# Altium schematic sheet 06_ATK-DLMP257_DEVICE.SchDoc
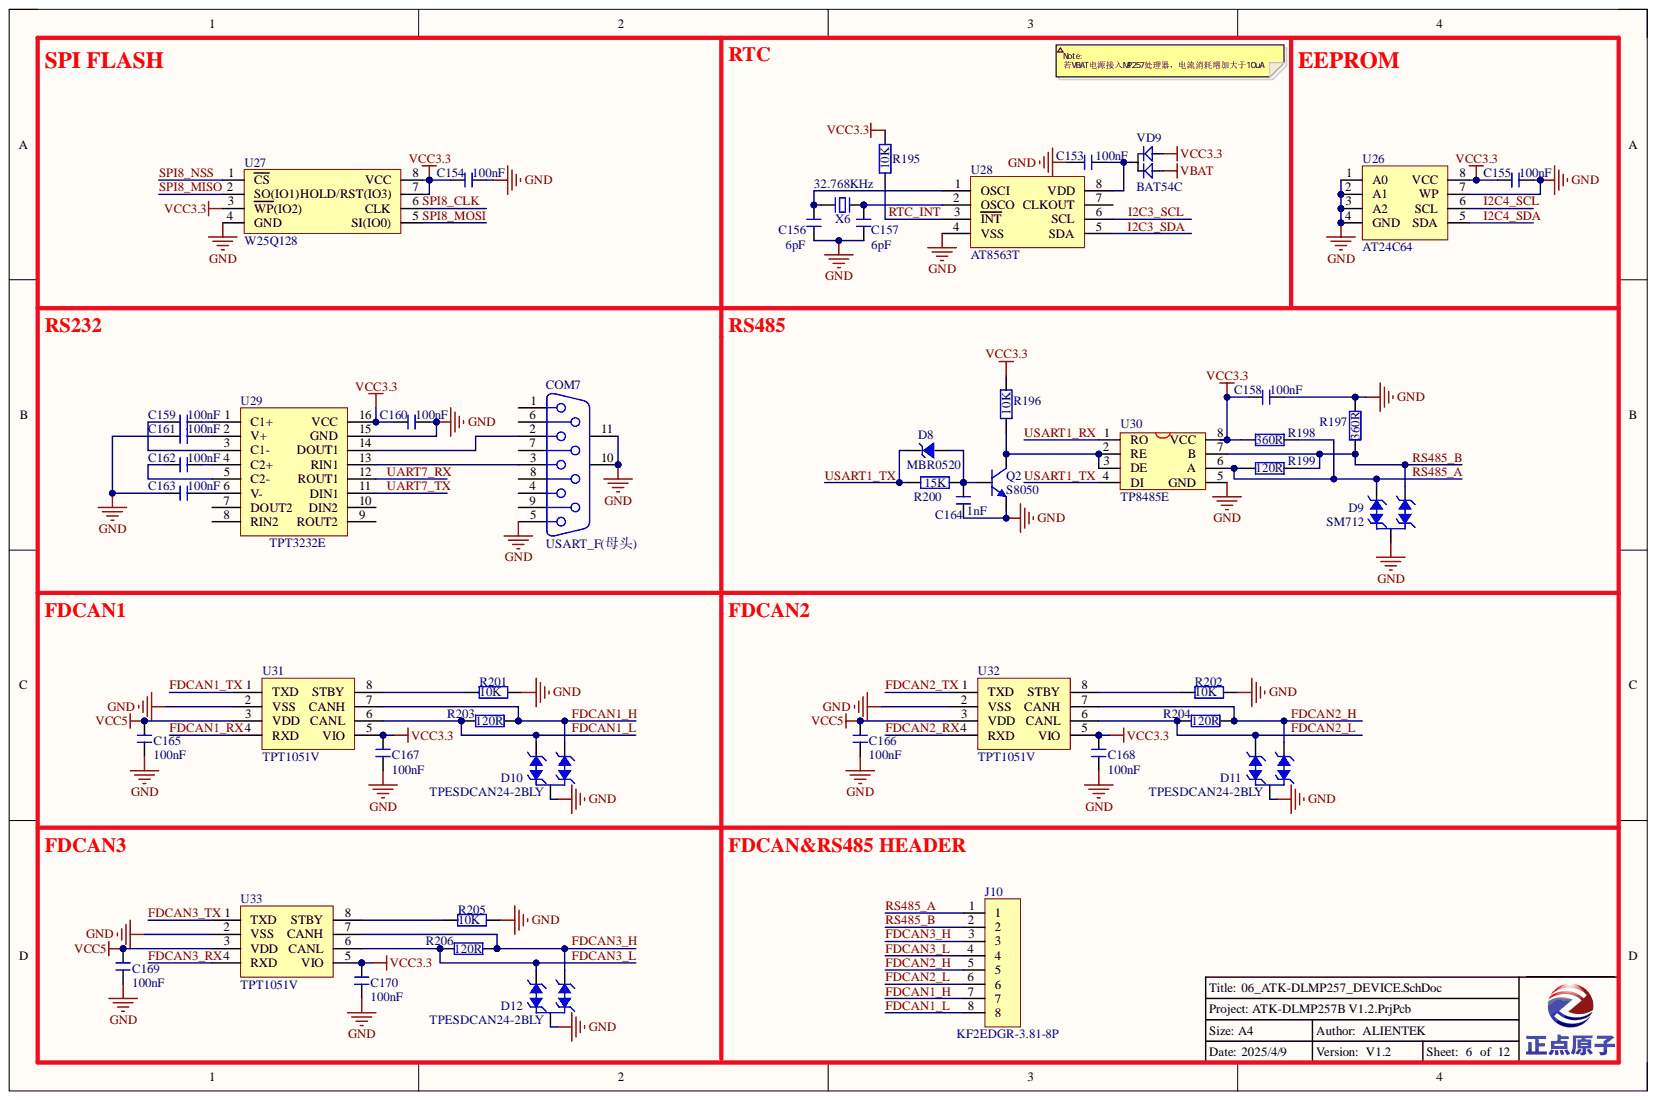
[diagram p0: 06_ATK-DLMP257_DEVICE.SchDoc]

## Parts
C153  100nF  [C 0603]
C154  100nF  [C 0603]
C155  100nF  [C 0603]
C156  6pF  [C 0603]
C157  6pF  [C 0603]
C158  100nF  [C 0603]
C159  100nF  [C 0603]
C160  100nF  [C 0603]
C161  100nF  [C 0603]
C162  100nF  [C 0603]
C163  100nF  [C 0603]
C164  1nF  [C 0603]
C165  100nF  [C 0603]
C166  100nF  [C 0603]
C167  100nF  [C 0603]
C168  100nF  [C 0603]
C169  100nF  [C 0603]
C170  100nF  [C 0603]
COM7  USART_F(母头)  [DB9/S_A]
D8  MBR0520  [SOD-123]
D9  SM712  [SOT23-3L]
D10  TPESDCAN24-2BLY  [SOT23-3L]
D11  TPESDCAN24-2BLY  [SOT23-3L]
D12  TPESDCAN24-2BLY  [SOT23-3L]
J10  KF2EDGR-3.81-8P  [KF2EDGR_3.81_8P]
Q2  S8050  [SOT23-3N]
R195  10K  [R 0603]
R196  10K  [R 0603]
R197  360R  [R 0603]
R198  360R  [R 0603]
R199  120R  [R 0603]
R200  15K  [R 0603]
R201  10K  [R 0603]
R202  10K  [R 0603]
R203  120R  [R 0603]
R204  120R  [R 0603]
R205  10K  [R 0603]
R206  120R  [R 0603]
U26  AT24C64  [SOP8_N]
U27  W25Q128  [SOP8W_N]
U28  AT8563T  [SOP8_N]
U29  TPT3232E  [SOP16_N]
U30  TP8485E  [SOP8_N]
U31  TPT1051V  [SOP8_N]
U32  TPT1051V  [SOP8_N]
U33  TPT1051V  [SOP8_N]
VD9  BAT54C  [SOT23-3N]
X6  32.768KHz  [XTAL-2X6H]

## Nets
GND: C153.1 C154.1 C155.1 C156.2 C157.2 C158.2 C160.2 C161.1 C163.1 C164.2 C165.2 C166.2 C167.2 C168.2 C169.2 C170.2 COM7.5 COM7.10 COM7.11 D9.3 D10.3 D11.3 D12.3 Q2.2 R197.1 R201.2 R202.2 R205.2 U26.1(A0) U26.2(A1) U26.3(A2) U26.4(GND) U26.7(WP) U27.4(GND) U28.4(VSS) U29.15(GND) U30.5(GND) U31.2(VSS) U32.2(VSS) U33.2(VSS)
VBAT: VD9.1
VCC3.3: C154.2 C155.2 C158.1 C160.1 C167.1 C168.1 C170.1 R195.1 R196.2 R198.2 U26.8(VCC) U27.3(WP(IO2)) U27.7(HOLD/RST(IO3)) U27.8(VCC) U29.16(VCC) U30.8(VCC) U31.5(VIO) U32.5(VIO) U33.5(VIO) VD9.2
VCC5: C165.1 C166.1 C169.1 U31.3(VDD) U32.3(VDD) U33.3(VDD)
FDCAN1_H: D10.1 J10.7 R203.1 U31.7(CANH)
FDCAN1_L: D10.2 J10.8 R203.2 U31.6(CANL)
FDCAN1_RX: U31.4(RXD)
FDCAN1_TX: U31.1(TXD)
FDCAN2_H: D11.1 J10.5 R204.1 U32.7(CANH)
FDCAN2_L: D11.2 J10.6 R204.2 U32.6(CANL)
FDCAN2_RX: U32.4(RXD)
FDCAN2_TX: U32.1(TXD)
FDCAN3_H: D12.1 J10.3 R206.1 U33.7(CANH)
FDCAN3_L: D12.2 J10.4 R206.2 U33.6(CANL)
FDCAN3_RX: U33.4(RXD)
FDCAN3_TX: U33.1(TXD)
I2C3_SCL: U28.6(SCL)
I2C3_SDA: U28.5(SDA)
I2C4_SCL: U26.6(SCL)
I2C4_SDA: U26.5(SDA)
RS485_A: D9.2 J10.1 R198.1 R199.2 U30.6(A)
RS485_B: D9.1 J10.2 R197.2 R199.1 U30.7(B)
RTC_INT: R195.2 U28.3(INT)
SPI8_CLK: U27.6(CLK)
SPI8_MISO: U27.2(SO(IO1))
SPI8_MOSI: U27.5(SI(IO0))
SPI8_NSS: U27.1(CS)
UART7_RX: U29.12(ROUT1)
UART7_TX: U29.11(DIN1)
USART1_RX: U30.1(RO)
USART1_TX: D8.2 R200.2 U30.4(DI)
NetC153_2: C153.2 U28.8(VDD) VD9.3
NetC156_1: C156.1 U28.1(OSCI) X6.1
NetC157_1: C157.1 U28.2(OSCO) X6.2
NetC159_1: C159.1 U29.3(C1-)
NetC159_2: C159.2 U29.1(C1+)
NetC161_2: C161.2 U29.2(V+)
NetC162_1: C162.1 U29.5(C2-)
NetC162_2: C162.2 U29.4(C2+)
NetC163_2: C163.2 U29.6(V-)
NetC164_1: C164.1 D8.1 Q2.1 R200.1
NetCOM7_2: COM7.2 U29.14(DOUT1)
NetCOM7_3: COM7.3 U29.13(RIN1)
NetQ2_3: Q2.3 R196.1 U30.2(RE) U30.3(DE)
NetR201_1: R201.1 U31.8(STBY)
NetR202_1: R202.1 U32.8(STBY)
NetR205_1: R205.1 U33.8(STBY)

Not connected: COM7 1 4 6 7 8 9; U28 7(CLKOUT); U29 7(DOUT2) 8(RIN2) 9(ROUT2) 10(DIN2)

## Library data of the parts
C153-C170 = C: 无极性贴片电容; 版权归属: 正点原子; BOM名称: 无极性贴片电容; Component Kind: Standard; 学习资源: www.openedv.com; Library Name: Lycreturn.IntLib
COM7 = DB9: 9针串口; 版权归属: 正点原子; BOM名称: 9针串口; Component Kind: Standard; 学习资源: www.openedv.com; Library Name: Lycreturn.IntLib
D8 = D: 稳压二极管; Component Kind: Standard; Library Name: 正点原子封装库V1.0.IntLib
D9-D12 = HDW24M2T-B: ESD; Component Kind: Standard; Library Name: ATK-DLMP257_V1.0.SchLib
J10 = KF2EDGR-3.81-8P: 弯脚,接线端子,8脚,间距3.81mm; Component Kind: Standard; Library Name: ATK-DLMP257_V1.0.IntLib
Q2 = 8050-SMD: 高频放大-NPN型; 属性: 40V 1.5A 1W 100MHZ; 版权归属: 正点原子; BOM名称: 高频放大-NPN型; Component Kind: Standard; 学习资源: www.openedv.com; Library Name: Lycreturn.IntLib
R195-R206 = R: 贴片电阻; 版权归属: 正点原子; BOM名称: 贴片电阻; Component Kind: Standard; 学习资源: www.openedv.com; Library Name: Lycreturn.IntLib
U26 = AT24CXX: 外置EEPROM; Parameter: 芯片; 版权归属: 正点原子; BOM名称: 外置EEPROM; Component Kind: Standard; Library Name: ATK-DLMP135-V1.0.IntLib; 学习资源: www.openedv.com
U27 = SPI_FLASH: Component Kind: Standard; Library Name: ATK-DLMP135-V1.0.IntLib
U28 = PCF8563: Component Kind: Standard; Library Name: ZZK_COMPONENT.IntLib
U29 = SP3232: RS232通讯芯片; 版权归属: 正点原子; BOM名称: RS232通讯芯片; Component Kind: Standard; 学习资源: www.openedv.com; Library Name: Lycreturn.IntLib
U30 = MAX3485: Component Kind: Standard; Library Name: Lycreturn.IntLib
U31-U33 = MCP2562FDT: Component Kind: Standard; Library Name: Lycreturn.IntLib
VD9 = BAT54C: 肖特基二极管（双）; Component Kind: Standard; Library Name: 二极管-整流桥.SchLib
X6 = XTAL-2P: 2脚无源晶振; BOM名称: 2脚晶振; 版权归属: 正点原子; Component Kind: Standard; 学习资源: www.openedv.com; Library Name: Lycreturn.IntLib
